# Altium schematic sheet Schematic_Orbita_Microcontroller.SchDoc (continued)
## Library data of the parts (continued)
R16 R19 = CMP-2002-00608-3: Chip Resistor, 100 KOhm, +/- 1%, 100 mW, -55 to 155 degC, 0402 (1005 Metric), RoHS, Tape and Reel; Manufacturer: Basic Passives; Case\/Package: 0402; Max Power Dissipation: 100mW; Power Rating: 100 mW; Temperature Coefficient: 100ppm/°C; Voltage Rating: 50 V; Voltage Rating (DC): 50V; Case Code (Imperial): 0402; Case Code (Metric): 1005; Case/Package: 0402; Category: Chip SMD Resistors; Component Kind: Standard; ComponentLink1Description: Manufacturer URL; ComponentLink1URL: http://www.panasonic.com/; ComponentLink2Description: Datasheet; ComponentLink2URL: http://industrial.panasonic.com/cdbs/www-data/pdf/RDA0000/AOA0000C86.pdf; ComponentLink3Description: https://datasheet.ciiva.com/11821/0900766b80f80784-11821175.pdf?src-supplier=RSComponents; ComponentLink3URL: https://datasheet.ciiva.com/11821/0900766b80f80784-11821175.pdf?src-supplier=RSComponents; ComponentLink4Description: https://datasheet.ciiva.com/34303/1987038-34303550.pdf?src-supplier=Jameco; ComponentLink4URL: https://datasheet.ciiva.com/34303/1987038-34303550.pdf?src-supplier=Jameco; ComponentLink5Description: https://datasheet.ciiva.com/7830/aoa0000ce2-7830725.pdf?src-supplier=Element14; ComponentLink5URL: https://datasheet.ciiva.com/7830/aoa0000ce2-7830725.pdf?src-supplier=Element14; ComponentLink6Description: https://datasheet.ciiva.com/16522/aoa0000ce2-16522647.pdf?src-supplier=Avnet+Asia; ComponentLink6URL: https://datasheet.ciiva.com/16522/aoa0000ce2-16522647.pdf?src-supplier=Avnet+Asia; ComponentLink7Description: https://datasheet.ciiva.com/43467/1678782-43467480.pdf?src-supplier=Farnell; ComponentLink7URL: https://datasheet.ciiva.com/43467/1678782-43467480.pdf?src-supplier=Farnell; ComponentLink8Description: https://datasheet.ciiva.com/16440/aoa0000ce2-16440217.pdf?src-supplier=Future+Electronics; ComponentLink8URL: https://datasheet.ciiva.com/16440/aoa0000ce2-16440217.pdf?src-supplier=Future+Electronics; ComponentLink9Description: https://datasheet.ciiva.com/48531/aoa0000ce2-48531070.pdf?src-supplier=Avnet; ComponentLink9URL: https://datasheet.ciiva.com/48531/aoa0000ce2-48531070.pdf?src-supplier=Avnet; ComponentLink10Description: https://datasheet.ciiva.com/9186/aoa0000ce2-9186687.pdf?src-supplier=Avnet; ComponentLink10URL: https://datasheet.ciiva.com/9186/aoa0000ce2-9186687.pdf?src-supplier=Avnet; ComponentLink11Description: https://datasheet.ciiva.com/16778/70260748-16778055.pdf?src-supplier=Allied+Electronics; ComponentLink11URL: https://datasheet.ciiva.com/16778/70260748-16778055.pdf?src-supplier=Allied+Electronics; ComponentLink12Description: https://datasheet.ciiva.com/30245/aoa0000ce2-30245691.pdf?src-supplier=Onlinecomponents.com; ComponentLink12URL: https://datasheet.ciiva.com/30245/aoa0000ce2-30245691.pdf?src-supplier=Onlinecomponents.com; ComponentLink13Description: https://datasheet.ciiva.com/7830/426256-7830974.pdf?src-supplier=Element14; ComponentLink13URL: https://datasheet.ciiva.com/7830/426256-7830974.pdf?src-supplier=Element14; ComponentLink14Description: https://datasheet.ciiva.com/16784/70260748-16784190.pdf?src-supplier=Allied+Electronics; ComponentLink14URL: https://datasheet.ciiva.com/16784/70260748-16784190.pdf?src-supplier=Allied+Electronics; ComponentLink15Description: https://datasheet.ciiva.com/22723/426256-22723902.pdf?src-supplier=Element14; ComponentLink15URL: https://datasheet.ciiva.com/22723/426256-22723902.pdf?src-supplier=Element14; Composition: Thick Film; Contact Plating: Tin; DatasheetVersion: 04/2015; Depth: 0.5mm; Features: Automotive AEC-Q200; Height: 0.35 mm; Lead Free: Lead Free; Length: 1 mm; Library Name: Altium Content Vault; Manufacturer Part Number: ERJ-2RKF1003X; Max Operating Temperature: 155 degC; Military Standard: Not; Min Operating Temperature: -55 degC; Mount: Surface Mount; Mounting Technology: Surface Mount; Number of Pins: 2; Number of Terminations: 2; Package Quantity: 10000; PackageDescription: 2-Pin Surface Mount Device, Body 1 x 0.5 mm; PackageReference: 0402; Packaging: Tape and Reel; PartNumber: ERJ-2RKF1003X; Pins: 2; Pricing 1: 1=0,10000, 10=0,04500, 100=0,01800, 1000=0,00800, 2500=0,00500, 10000=0,00400 (USD); Radiation Hardening: No; REACH SVHC: Yes; RoHS: Yes; RoHSCompliant: true; Supplier 1: Mouser; Supplier Part Number 1: 667-ERJ-2RKF1003X; Termination: SMD/SMT; Tolerance: 1%; Width: 0.5 mm
  R16: Resistance: 100 KOhm; Stock 1: 1586937
  R19: Stock 1: 1581193
R20 = CMP-2100-03667-1: Chip Resistor, 328 Ohm, +/- 5%, 0.1 W, -55 to 155 degC, 0603 (1608 Metric), RoHS, Tape and Reel; Manufacturer: Yageo; Max Power Dissipation: 100mW; Temperature Coefficient: 200ppm/degreesC; Voltage Rating: 50V; Case Code (Imperial): 0603; Case Code (Metric): 1608; Case/Package: 0603; Category: Chip SMD Resistors; Component Kind: Standard; ComponentLink1Description: http://www.mouser.com/ds/2/447/yageodocoutput-1125656.pdf?src-supplier=Mouser; ComponentLink1URL: http://www.mouser.com/ds/2/447/yageodocoutput-1125656.pdf?src-supplier=Mouser; ComponentLink2Description: http://www.yageo.com/documents/recent/PYu-RT_1-to-0.01_RoHS_L_9.pdf?src-supplier=Digi-Key; ComponentLink2URL: http://www.yageo.com/documents/recent/PYu-RT_1-to-0.01_RoHS_L_9.pdf?src-supplier=Digi-Key; Composition: ThickFilm; Contact Plating: Tin; Datasheet URL: http://www.yageo.com/NewPortal/yageodocoutput?fileName=/pdf/R-Chip/PYu-RC_Group_51_RoHS_L_5.pdf; Datasheet Version: Rev. 5, 10/2016; Depth: 0.8mm; Features: Moisture Resistant; Height: 0.45mm; Lead Free: LeadFree; Length: 1.6mm; Library Name: Altium Content Vault; Manufacturer Part Number: RT0603DRE07328RL; Manufacturer URL: http://www.yageo.com/; Max Operating Temperature: 155degC; Military Standard: Not; Min Operating Temperature: -55degC; Number of Pins: 2; Number of Terminations: 2; Package Description: 2-Pin Surface Mount Device, Body 1.6 x 0.8 mm; Package Quantity: 5000; Package Reference: 0603; Packaging: TapeandReel; Power Rating: 100mW; Pricing 1: 1=0,13000, 10=0,07400, 100=0,02600, 1000=0,01800, 5000=0,01300, 10000=0,01100 (USD); Radiation Hardening: No; REACH SVHC: NoSVHC; RoHS: Yes; RoHSCompliant: true; Stock 1: 14328; Supplier 1: Mouser; Supplier Part Number 1: 603-RT0603DRE07328RL; Termination: SMD/SMT; Tolerance: 5%; Voltage Rating (DC): 50V; Width: 0.8mm
U7 = STM32G474RET6: Manufacturer: STMicroelectronics; Component Kind: Standard; Manufacturer Part Number: STM32G474RET6; Pricing 1: 1=7,68000, 10=6,36000, 25=6,05000, 50=5,67000, 100=5,28000, 250=5,04000, 500=4,09000 (EUR); Pricing 2: 1=6,82000, 10=6,17000, 25=5,88000, 100=5,10000, 250=4,87000, 500=4,44000 (USD); RoHS: No; Stock 1: 57; Stock 2: 660; Supplier 1: Farnell; Supplier 2: Mouser; Supplier Part Number 1: 3132418; Supplier Part Number 2: 511-STM32G474RET6; Library Name: IntegratedSchematicLib_OrbitaProject.SchLib
U8 = ST3485ECDR: IC TXRX RS422/485 15MBPS 8SO; Manufacturer: STMicroelectronics; Interface: RS-422,RS-485; Logic Function: Transceiver; Max Supply Voltage: 3.6V; Min Supply Voltage: 3V; Nominal Supply Current: 2.2mA; Number of Drivers: 1; Operating Supply Voltage: 3.3V; Case/Package: SOIC; Category: Interface ICs; Component Kind: Standard; ComponentLink1Description: https://datasheet.ciiva.com/7630/1761326-7630115.pdf?src-supplier=Element14; ComponentLink1URL: https://datasheet.ciiva.com/7630/1761326-7630115.pdf?src-supplier=Element14; ComponentLink2Description: https://datasheet.ciiva.com/22694/1788494-22694373.pdf?src-supplier=Element14; ComponentLink2URL: https://datasheet.ciiva.com/22694/1788494-22694373.pdf?src-supplier=Element14; ComponentLink3Description: https://datasheet.ciiva.com/26830/st3485ecdr-26830187.pdf?src-supplier=IIIC; ComponentLink3URL: https://datasheet.ciiva.com/26830/st3485ecdr-26830187.pdf?src-supplier=IIIC; ComponentLink4Description: https://datasheet.ciiva.com/1703/cd00003137-1703691.pdf?src-supplier=Digi-Key; ComponentLink4URL: https://datasheet.ciiva.com/1703/cd00003137-1703691.pdf?src-supplier=Digi-Key; Data Rate: 15Mbps; Height: 1.65mm; Lead Free: Lead Free; Length: 5mm; Manufacturer Part Number: ST3485ECDR; Max Operating Temperature: 70°C; Min Operating Temperature: 0°C; Mount: Surface Mount; Number of Pins: 8; Number of Receivers: 1; Number of Transceivers: 1; Number of Transmitters: 1; Package Quantity: 1; Packaging: Tape and Reel; Pricing 1: 25=1,08000, 125=0,83200, 625=0,79800, 1250=0,76800 (EUR); Pricing 2: 1=1,54000, 10=1,31000, 100=1,05000, 500=0,91900, 1000=0,76100, 2500=0,70900 (USD); Radiation Hardening: No; REACH SVHC: No SVHC; RoHS: Yes; Stock 1: 8905; Stock 2: 6221; Supplier 1: RSComponents; Supplier 2: Mouser; Supplier Part Number 1: 7959041P; Supplier Part Number 2: 511-ST3485ECDR; Width: 4mm; Library Name: IntegratedLibProject_Orbita.IntLib
U9 = CMP-0323-00337-3: Buck Step Down Regulator with 3 to 17 V Input and 3.3 V Output, -40 to 85 degC, 8-Pin WSON (DSG), Green (RoHS & no Sb/Br); Manufacturer: Texas Instruments; Duty Cycle(Max)(%): 100; Iout(Max)(A): 0.5; Iq(Typ)(mA): 0.017; Max Input Voltage: 17V; Max Output Current: 500mA; Max Output Voltage: 3.3V; Min Input Voltage: 3V; Number of Outputs: 1; Output Configuration: Positive; Output Type: Fixed; Preset Vout(V): 3.3; Quiescent Current: 17uA; Rating: Catalog; SubFamily: Step-Down Regulator; Switch Current Limit(Typ)(A): 1.05; Switching Frequency: 2.25MHz; Synchronous Rectification: TRUE; Synchronous Rectifier: Yes; Vin(Max)(V): 17; Vin(Min)(V): 3; Category: Power Supply Modules; Code_JEDEC: MO-229; Component Kind: Standard; ComponentLink1Description: Datasheet; ComponentLink1URL: http://www.ti.com/general/docs/lit/getliterature.tsp?genericPartNumber=TPS62172&fileType=pdf; ComponentLink2Description: Package Specification; ComponentLink2URL: http://www.ti.com/litv/pdf/mpds308a; ComponentLink3Description: URL Link1; ComponentLink3URL: Samples URL:http://www.ti.com/product/tps62172#samples; ComponentLink4Description: https://datasheet.ciiva.com/26100/tps62170-26100704.pdf?src-supplier=Avnet+Europe; ComponentLink4URL: https://datasheet.ciiva.com/26100/tps62170-26100704.pdf?src-supplier=Avnet+Europe; ComponentLink5Description: https://datasheet.ciiva.com/2034/tps62171-2034449.pdf?src-supplier=Digi-Key; ComponentLink5URL: https://datasheet.ciiva.com/2034/tps62171-2034449.pdf?src-supplier=Digi-Key; ComponentLink6Description: https://datasheet.ciiva.com/14377/tps62170-14377134.pdf?src-supplier=Avnet; ComponentLink6URL: https://datasheet.ciiva.com/14377/tps62170-14377134.pdf?src-supplier=Avnet; Contact Plating: Gold; DatasheetVersion: SLVSAT8A; Eco-Plan: Green (RoHS & no Sb/Br); Lead Free: Lead Free; Library Name: Altium Content Vault; Manufacturer Part Number: TPS62172DSGR; Max Operating Temperature: 125°C; Min Operating Temperature: -40°C; Min Output Voltage: 3.3V; Mounting Technology: Surface Mount; Number of Pins: 8; Operating Supply Voltage: 17V; Output Current: 500mA; Output Voltage: 3.3V; Package Quantity: 3000; PackageDescription: 8-Pin Plastic Small Outline No-Lead, 2 x 2 x 0.8 mm Body, 0.5 mm Pitch, Exposed Pad; PackageReference: DSG0008A; PackageVersion: revB, Oct-2010; Packaging: Tape and Reel; PartNumber: TPS62172DSGR; Pricing 1: 1=1,47000, 10=1,26000, 100=0,87800, 500=0,83200, 1000=0,64700, 3000=0,57400 (USD); Radiation Hardening: No; REACH SVHC: No SVHC; Regulated Outputs(#): 1; RoHS: Yes; Stock 1: 35476; Supplier 1: Mouser; Supplier Part Number 1: 595-TPS62172DSGR; Topology: Buck; Vout(Max)(V): 3.3; Vout(Min)(V): 3.3
Y1 = CMP-2000-07595-1: Crystal Unit, 8 MHz, 8 pF, -40 to 150 degC, 2-Pin SMD, RoHS, Tape and Reel; Manufacturer: NDK; ESR (Equivalent Series Resistance): 500Ohm; Frequency Stability: 150ppm; Frequency Tolerance: 50ppm; Load Capacitance: 8pF; Case/Package: SMD/SMT; Category: Crystals; Component Kind: Standard; ComponentLink1Description: https://datasheet.ciiva.com/6732/c-nx3225gd-std-cra-3-e-6732944.pdf?src-supplier=Digi-Key; ComponentLink1URL: https://datasheet.ciiva.com/6732/c-nx3225gd-std-cra-3-e-6732944.pdf?src-supplier=Digi-Key; Datasheet URL: http://www.ndk.com/images/products/catalog/c_NX3225GD-STD-CRA-3_e.pdf; Datasheet Version: 11/2016; Height: 0.039inch; Length: 0.126inch; Library Name: Altium Content Vault; Manufacturer Part Number: NX3225GD-8MHZ-STD-CRA-3; Manufacturer URL: http://www.ndk.com/en/; Max Operating Temperature: 150degC; Min Operating Temperature: -40degC; Mount: SurfaceMount; Mounting Technology: SurfaceMount; Number of Pins: 2; Package Description: 2-Pin Surface Mount Device, Body 3.2 x 2.5 mm; Package Quantity: 3000; Package Reference: NX3225GD-8MHZ-STD-CRA-3; Packaging: TapeandReel; Pricing 1: 1=0,94000, 10=0,78100, 100=0,69000, 500=0,65400, 1000=0,54500, 3000=0,47900 (USD); RoHS: Yes; RoHSCompliant: True; Stock 1: 10574; Supplier 1: Mouser; Supplier Part Number 1: 344-NX3225GD8MCRA3; Width: 0.098inch
